# Altium schematic sheet Esquema_Principal.SchDoc
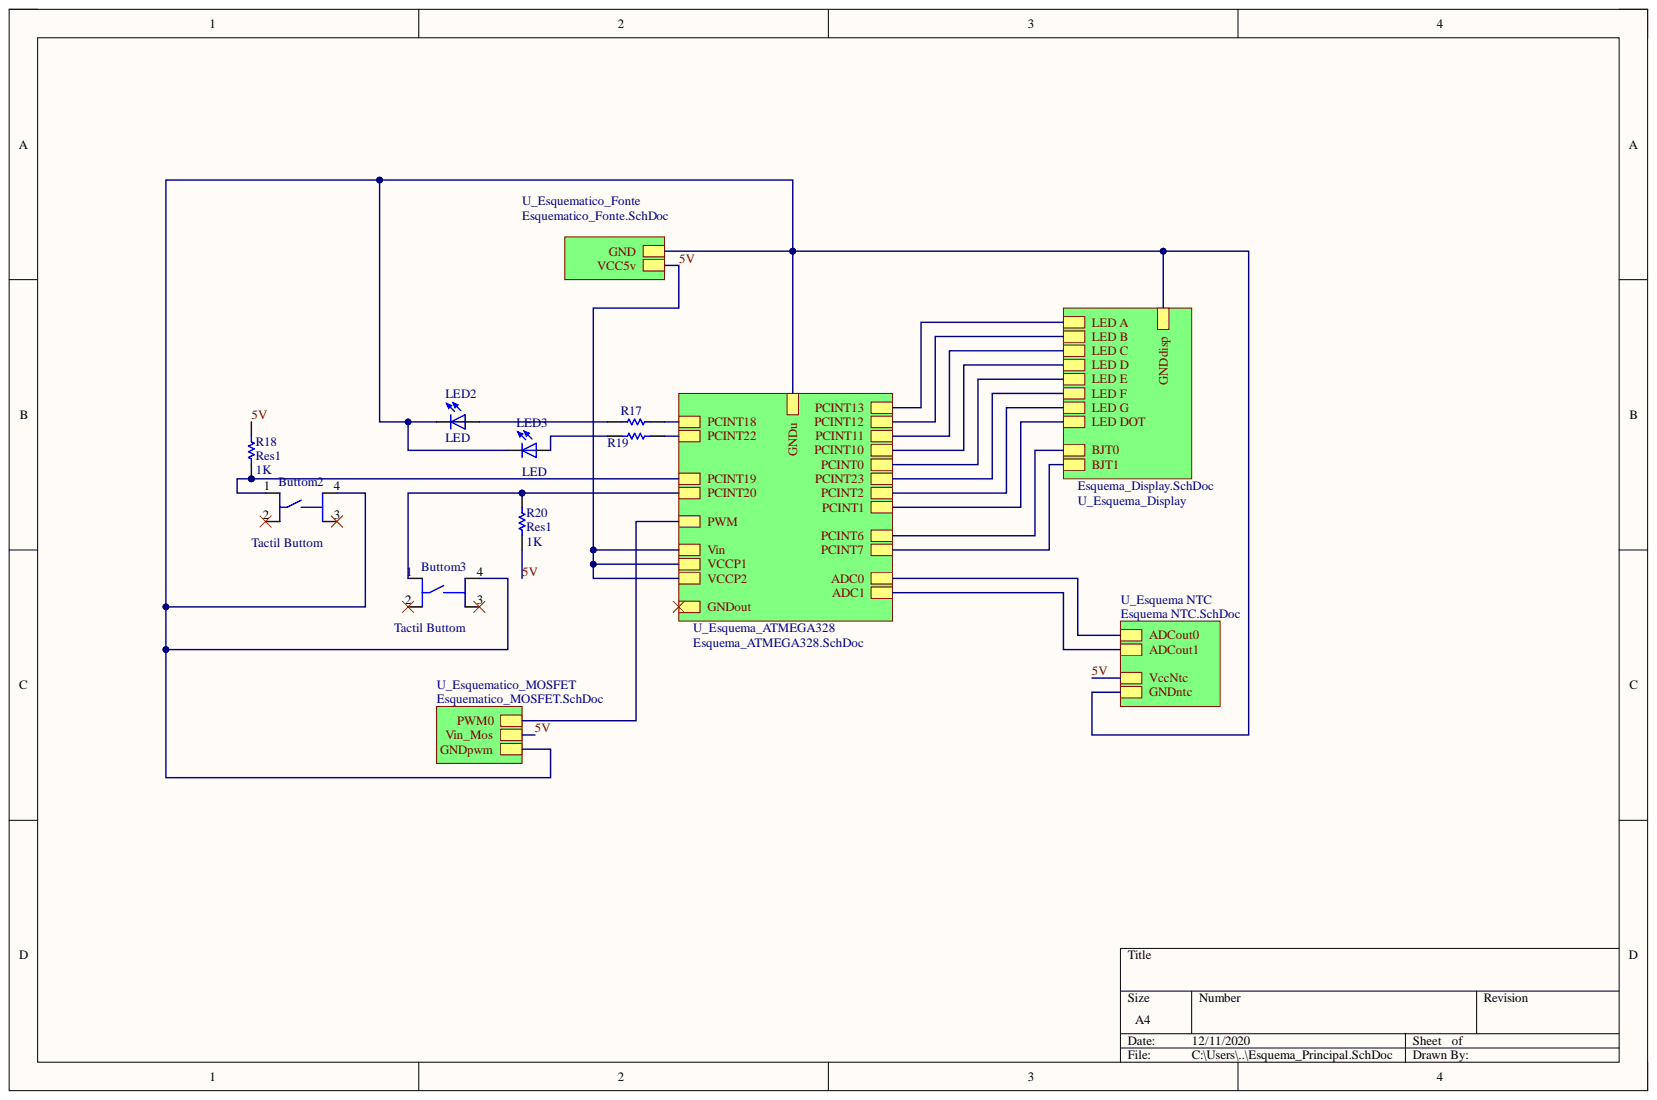
[diagram p0: Esquema_Principal.SchDoc]

## Parts
Buttom2  Tactil Buttom  [Tactil Buttom]
Buttom3  Tactil Buttom  [Tactil Buttom]
LED2  LED  [Led_THT]
LED3  LED  [Led_THT]
R17  Res1  [AXIAL-0.3]  (value not drawn)
R18  Res1  value 1K  [AXIAL-0.3]
R19  Res1  [AXIAL-0.3]  (value not drawn)
R20  Res1  value 1K  [AXIAL-0.3]

## Nets
5V: R18.2 R20.1
NetButtom2_1: Buttom2.1 R18.1
NetButtom2_2: Buttom2.2
NetButtom2_3: Buttom2.3
NetButtom2_4: Buttom2.4 Buttom3.4 LED2.K LED3.K
NetButtom3_1: Buttom3.1 R20.2
NetButtom3_2: Buttom3.2
NetButtom3_3: Buttom3.3
NetLED2_A: LED2.A R17.1
NetLED3_A: LED3.A R19.1
NetR17_2: R17.2
NetR19_2: R19.2
ADC0:
ADC1:
BJT0:
BJT1:
GNDout:
LED A:
LED B:
LED C:
LED D:
LED DOT:
LED E:
LED F:
LED G:
PWM:

## Library data of the parts
R17 R19 = Res1: Resistor; Value: 1K; Component Kind: Standard; LatestRevisionDate: 17-Jul-2002; LatestRevisionNote: Re-released for DXP Platform.; Library Name: Miscellaneous Devices.IntLib; PackageDescription: Axial Device, Thru-Hole; 2 Leads; 0.3 in Pin Spacing; PackageReference: AXIAL-0.3; Published: 8-Jun-2000; Signal Integrity: Res; Simulation: RESISTOR; Publisher: Altium Limited
R18 R20 = Res1: Resistor; Component Kind: Standard; LatestRevisionDate: 17-Jul-2002; LatestRevisionNote: Re-released for DXP Platform.; Library Name: Miscellaneous Devices.IntLib; PackageDescription: Axial Device, Thru-Hole; 2 Leads; 0.3 in Pin Spacing; PackageReference: AXIAL-0.3; Published: 8-Jun-2000; Signal Integrity: Res; Simulation: RESISTOR; Publisher: Altium Limited
Buttom2 Buttom3 = Tactil Buttom: Component Kind: Standard; Library Name: myLibAltium.SchLib
LED2 LED3 = LED: Component Kind: Standard; Library Name: myLibAltium.SchLib
